# Altium schematic sheet Logic.SchDoc(Logic)
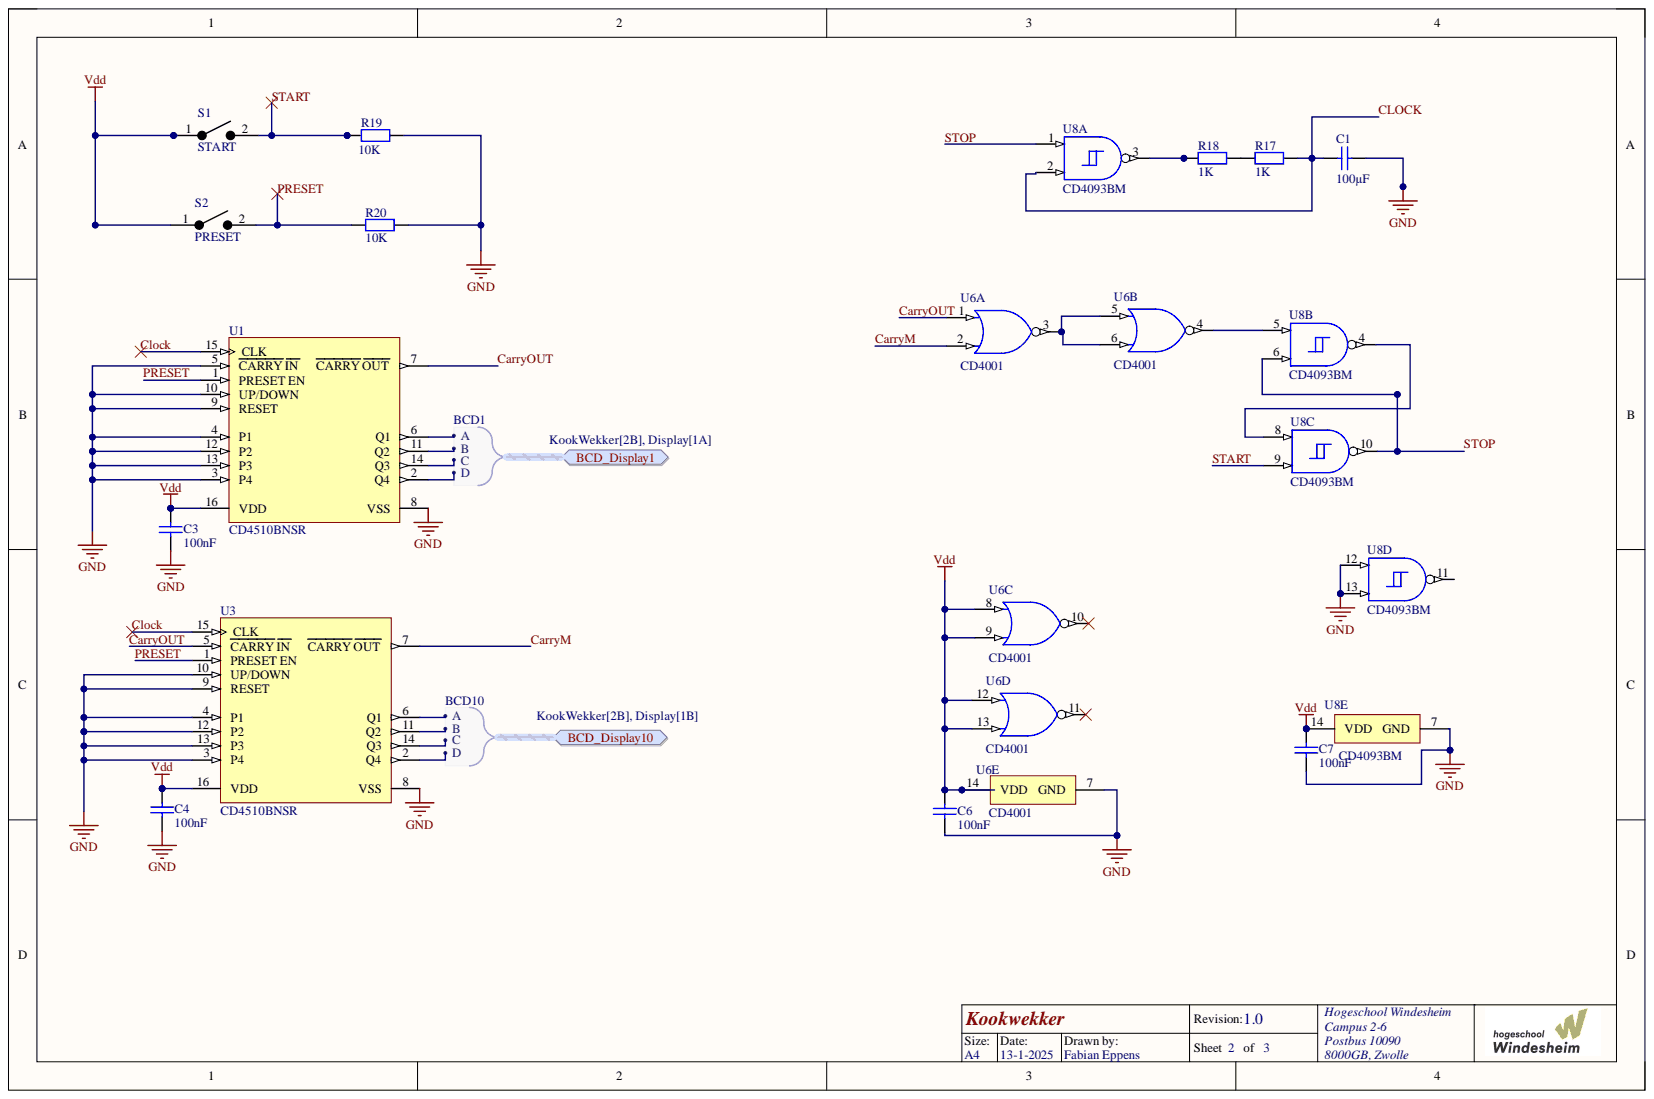
[diagram p0: Logic.SchDoc]

## Parts
C1  100µF  [C1206]
C3  100nF  [C1206]
C4  100nF  [C1206]
C6  100nF  [C1206]
C7  100nF  [C1206]
R17  1K  [R1206]
R18  1K  [R1206]
R19  10K  [R1206]
R20  10K  [R1206]
S1  START  [1478731]
S2  PRESET  [1478731]
U1  CD4510BNSR  [NS0016A_N]
U3  CD4510BNSR  [NS0016A_N]
U6  CD4001  [D0014A_N]  (parts A,B,C,D,E)
U8  CD4093BM  [D0014A_N]  (parts A,B,C,D,E)

## Ports
BCD_Display1, BCD_Display1.A, BCD_Display1.B, BCD_Display1.C, BCD_Display1.D, BCD_Display10, BCD_Display10.A, BCD_Display10.B, BCD_Display10.C, BCD_Display10.D

## Nets
GND: C1.2 C3.2 C4.2 C6.1 C7.1 R19.2 R20.2 U1.3(P4) U1.4(P1) U1.5(CARRY_IN) U1.8(VSS) U1.9(RESET) U1.10(UP/DOWN) U1.12(P2) U1.13(P3) U3.3(P4) U3.4(P1) U3.8(VSS) U3.9(RESET) U3.10(UP/DOWN) U3.12(P2) U3.13(P3) U6.7(GND) U8.7(GND) U8.12 U8.13
Vdd: C3.1 C4.1 C6.2 C7.2 S1.1 S2.1 U1.16(VDD) U3.16(VDD) U6.8 U6.9 U6.12 U6.13 U6.14(VDD) U8.14(VDD)
CarryM: U3.7(CARRY_OUT) U6.2
CarryOUT: U1.7(CARRY_OUT) U3.5(CARRY_IN) U6.1
Clock: C1.1 R17.2 U1.15(CLK) U3.15(CLK) U8.2
PRESET: R20.1 S2.2 U1.1(PRESET_EN) U3.1(PRESET_EN)
START: R19.1 S1.2 U8.9
STOP: U8.1 U8.6 U8.10
NetR17_1: R17.1 R18.2
NetR18_1: R18.1 U8.3
NetU1_2: U1.2(Q4) ; port BCD_Display1
NetU1_6: U1.6(Q1) ; port BCD_Display1
NetU1_11: U1.11(Q2) ; port BCD_Display1
NetU1_14: U1.14(Q3) ; port BCD_Display1
NetU3_2: U3.2(Q4) ; port BCD_Display10
NetU3_6: U3.6(Q1) ; port BCD_Display10
NetU3_11: U3.11(Q2) ; port BCD_Display10
NetU3_14: U3.14(Q3) ; port BCD_Display10
NetU6_3: U6.3 U6.5 U6.6
NetU6_4: U6.4 U8.5
NetU6_10: U6.10
NetU6_11: U6.11
NetU8_4: U8.4 U8.8
NetU8_11: U8.11

## Library data of the parts
C1 C3 C4 C6 C7 = Capacitor_1206: SMD Capacitor 1206; Component Kind: Standard; LatestRevisionDate: 03-11-2016; LatestRevisionNote: Creation; PackageDescription: Chip Capacitor, Body 3.2x1.6mm, Height 1.7mm, IPC Medium Density; PackageReference: C1206; Published: 03-11-2016; Signal Integrity: Cap; Simulation: CAP; Library Name: KookwekkerLibrary_v5_1.IntLib; Publisher: Windesheim
  C1: Value: 100µF
  C3 C4 C6 C7: Value: 100nF
R17-R20 = Resistor_1206: SMD Resistor 1206; Component Kind: Standard; LatestRevisionDate: 03-11-2016; LatestRevisionNote: Creation; PackageDescription: Chip Resistor, Body 3.2x1.6mm, IPC Medium Density; PackageReference: R1206; Published: 03-11-2016; Signal Integrity: Res; Simulation: RESISTOR; Library Name: KookwekkerLibrary_v5_1.IntLib; Publisher: Windesheim
  R17 R18: Value: 1K
  R19 R20: Value: 10K
S1 S2 = Switch: Manufacturer_Name: TE Connectivity; Manufacturer_Part_Number: 147873-1; Component Kind: Standard; Datasheet Link: https://componentsearchengine.com/Datasheets/1/147873-1.pdf; Description: Switch; Height: 5mm; Mouser Part Number: 506-147873-1; Mouser Price/Stock: https://www.mouser.com/Search/Refine.aspx?Keyword=506-147873-1; Library Name: KookwekkerLibrary_v5_1.IntLib
U1 U3 = CD4510BNSR: CMOS Presettable BCD Up/Down Counter, NS0016A, LARGE T&R; Manufacturer: Texas Instruments; Bits(#): 4; F @ Nom Voltage(Max)(Mhz): 8; ICC @ Nom Voltage(Max)(mA): 0.03; Inputs: 1; Output Drive (IOL/IOH)(Max)(mA): 1.5/-1.5; Rating: Catalog; Schmitt Trigger: No; Technology Family: CD4000; tpd @ Nom Voltage(Max)(ns): 200; VCC(Max)(V): 18; VCC(Min)(V): 3; Voltage(Nom)(V): 5,10,15; Component Kind: Standard; DatasheetVersion: SCHS071B; Function: Counter; Generic Part Number: CD4510B; Input Type: CMOS; Operating Temperature Range(C): -55 to 125; Output Type: CMOS; PackageReference: NS0016A; Type: Other; Library Name: KookwekkerLibrary_v5_1.IntLib
U6 = CD4001: CMOS Quad 2-Input NOR Schmitt Triggers, D0014A, TUBE; Generic Part Number: CD4093B; Manufacturer: Texas Instruments; Bits(#): 4; F @ Nom Voltage(Max)(Mhz): 8; ICC @ Nom Voltage(Max)(mA): 0.06; Output Drive (IOL/IOH)(Max)(mA): 1.5/-1.5; Rating: Catalog; Schmitt Trigger: Yes; Technology Family: CD4000; VCC(Max)(V): 18; VCC(Min)(V): 3; Voltage(Nom)(V): 10; Code_JEDEC: MS-012; Component Kind: Standard; DatasheetVersion: SCHS115D; Input Type: CMOS; Operating Temperature Range(C): -55 to 125; Output Type: CMOS; PackageReference: D0014A; Library Name: KookwekkerLibrary_v5_1.IntLib
U8 = CD4093BM: CMOS Quad 2-Input NAND Schmitt Triggers, D0014A, TUBE; Manufacturer: Texas Instruments; Bits(#): 4; F @ Nom Voltage(Max)(Mhz): 8; ICC @ Nom Voltage(Max)(mA): 0.06; Output Drive (IOL/IOH)(Max)(mA): 1.5/-1.5; Rating: Catalog; Schmitt Trigger: Yes; Technology Family: CD4000; VCC(Max)(V): 18; VCC(Min)(V): 3; Voltage(Nom)(V): 10; Code_JEDEC: MS-012; Component Kind: Standard; DatasheetVersion: SCHS115D; Generic Part Number: CD4093B; Input Type: CMOS; Operating Temperature Range(C): -55 to 125; Output Type: CMOS; PackageReference: D0014A; Library Name: KookwekkerLibrary_v5_1.IntLib
